# Altium schematic sheet Wifi.SchDoc
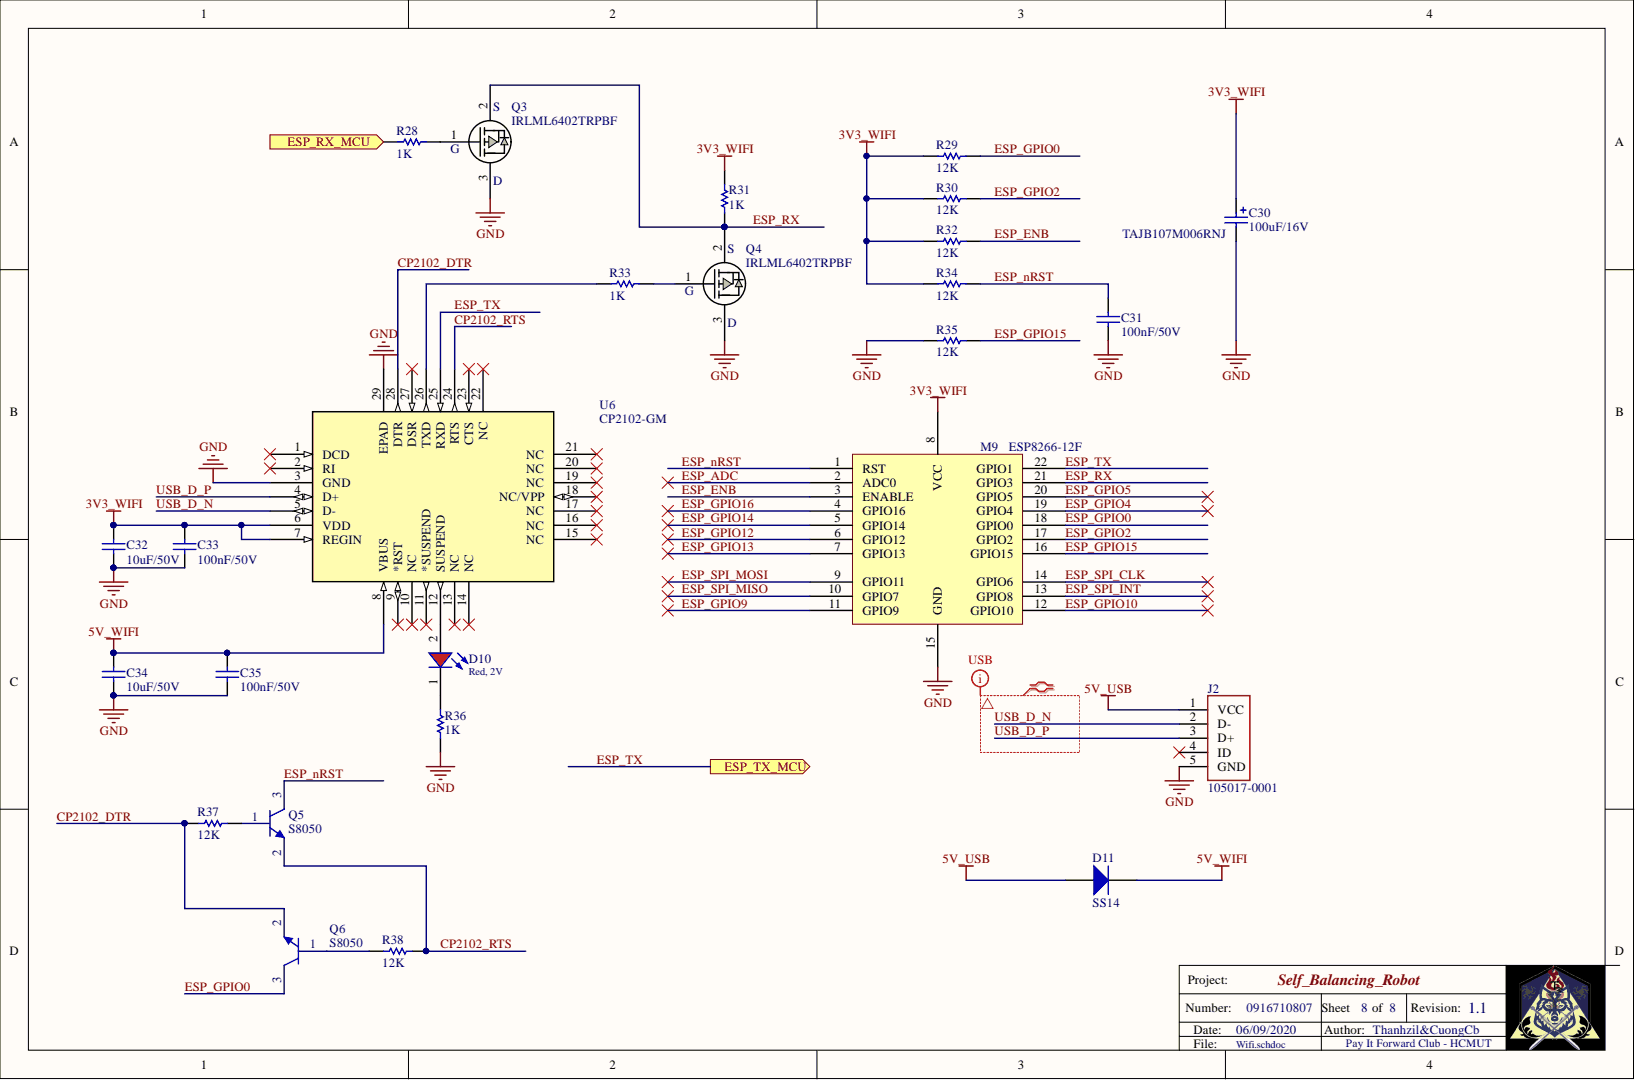
[diagram p0: Wifi.SchDoc]

## Parts
C30  TAJB107M006RNJ  value 100uF/16V  [CAP_SM_TAN]
C31  value 100nF/50V  [CAP-SM-0805]  (hidden comment: Cap-100nF-50V-0805)
C32  value 10uF/50V  [CAP-SM-0805]  (hidden comment: Cap-10uF-50V-0805)
C33  value 100nF/50V  [CAP-SM-0805]  (hidden comment: Cap-100nF-50V-0805)
C34  value 10uF/50V  [CAP-SM-0805]  (hidden comment: Cap-10uF-50V-0805)
C35  value 100nF/50V  [CAP-SM-0805]  (hidden comment: Cap-100nF-50V-0805)
D10  Red, 2V  Forward Voltage typ.: 2V  [WL-SMCW_0805]
D11  SS14  [SS14]
J2  105017-0001  [CONN_105017-0001]
M9  ESP8266-12F  [ESP8266-12F]
Q3  IRLML6402TRPBF  [SOT23_NEX]
Q4  IRLML6402TRPBF  [SOT23_NEX]
Q5  S8050  [SOT23_NEX]
Q6  S8050  [SOT23_NEX]
R28  value 1K  [RES-SM-0805]  (hidden comment: Res-1K-0805)
R29  value 12K  [RES-SM-0805]  (hidden comment: Res-12K-0805)
R30  value 12K  [RES-SM-0805]  (hidden comment: Res-12K-0805)
R31  value 1K  [RES-SM-0805]  (hidden comment: Res-1K-0805)
R32  value 12K  [RES-SM-0805]  (hidden comment: Res-12K-0805)
R33  value 1K  [RES-SM-0805]  (hidden comment: Res-1K-0805)
R34  value 12K  [RES-SM-0805]  (hidden comment: Res-12K-0805)
R35  value 12K  [RES-SM-0805]  (hidden comment: Res-12K-0805)
R36  value 1K  [RES-SM-0805]  (hidden comment: Res-1K-0805)
R37  value 12K  [RES-SM-0805]  (hidden comment: Res-12K-0805)
R38  value 12K  [RES-SM-0805]  (hidden comment: Res-12K-0805)
U6  CP2102-GM  [CP2102-GM]

## Ports
ESP_RX_MCU, ESP_TX_MCU

## Nets
3V3_WIFI: C30.1 C32.1 C33.2 M9.8(VCC) R29.1 R30.1 R31.2 R32.1 R34.1 U6.6(VDD) U6.7(REGIN)
5V_USB: D11.2 J2.1(VCC)
5V_WIFI: C34.2 C35.2 D11.1 U6.8(VBUS)
GND: C30.2 C31.1 C32.2 C33.1 C34.1 C35.1 J2.5(GND) M9.15(GND) Q3.3 Q4.3 R35.1 R36.1 U6.3(GND) U6.29(EPAD)
CP2102_DTR: Q6.2 R37.1 U6.28(DTR)
CP2102_RTS: Q5.2 R38.2 U6.24(RTS)
ESP_ADC: M9.2(ADC0)
ESP_ENB: M9.3(ENABLE) R32.2
ESP_GPIO0: M9.18(GPIO0) Q6.3 R29.2
ESP_GPIO2: M9.17(GPIO2) R30.2
ESP_GPIO4: M9.19(GPIO4)
ESP_GPIO5: M9.20(GPIO5)
ESP_GPIO9: M9.11(GPIO9)
ESP_GPIO10: M9.12(GPIO10)
ESP_GPIO12: M9.6(GPIO12)
ESP_GPIO13: M9.7(GPIO13)
ESP_GPIO14: M9.5(GPIO14)
ESP_GPIO15: M9.16(GPIO15) R35.2
ESP_GPIO16: M9.4(GPIO16)
ESP_nRST: C31.2 M9.1(RST) Q5.3 R34.2
ESP_RX: M9.21(GPIO3) Q3.2 Q4.2 R31.1
ESP_RX_MCU: R28.1 ; port ESP_RX_MCU
ESP_SPI_CLK: M9.14(GPIO6)
ESP_SPI_INT: M9.13(GPIO8)
ESP_SPI_MISO: M9.10(GPIO7)
ESP_SPI_MOSI: M9.9(GPIO11)
ESP_TX: M9.22(GPIO1) U6.25(RXD) ; port ESP_TX_MCU
USB_D_N: J2.2(D-) U6.5(D-)
USB_D_P: J2.3(D+) U6.4(D+)
NetD10_1: D10.1 R36.2
NetD10_2: D10.2 U6.12(SUSPEND)
NetQ3_1: Q3.1 R28.2
NetQ4_1: Q4.1 R33.1
NetQ5_1: Q5.1 R37.2
NetQ6_1: Q6.1 R38.1
NetR33_2: R33.2 U6.26(TXD)
N00269:
N00270:

Not connected: J2 4(ID); U6 1(DCD) 2(RI) 9(*RST) 10(NC) 11(*SUSPEND) 13(NC) 14(NC) 15(NC) 16(NC) 17(NC) 18(NC/VPP) 19(NC) 20(NC) 21(NC) 22(NC) 23(CTS) 27(DSR)

## Library data of the parts
C30 = Cap-Tan-100uF-16V: Manufacturer: Nichicon; Manufacturer Part Number: UWX1C101MCL1GB; Component Kind: Standard; Library Name: Capacitor.SchLib; Local Supplier: icdayroi; Local Supplier Link: https://icdayroi.com/tu-tantalum-100uf-16v-smd6032; Supplier 1: Digikey; Supplier 1 Link: https://www.digikey.com/product-detail/en/nichicon/UWX1C101MCL1GB/493-2105-1-ND/590080; Supplier 1 Part Number: 493-2105-1-ND
C31 C33 C35 = Cap-100nF-50V-0805: CAP CER 0.1UF 50V Y5V 0805; Manufacturer: Samsung Electro-Mechanics; Manufacturer Part Number: CL21F104ZBCNNNC; Component Kind: Standard; Library Name: Capacitor.SchLib; Local Supplier: thegioiic; Local Supplier Link: https://thegioiic.com/products/tu-100nf-0-1uf-50v-0805; Supplier 1: Digikey; Supplier 1 Link: https://www.digikey.com/product-detail/en/samsung-electro-mechanics/CL21F104ZBCNNNC/1276-1007-1-ND/3889093; Supplier 1 Part Number: 1276-1007-1-ND
C32 C34 = Cap-10uF-50V-0805: CAP CER 10UF 50V X5R 0805; Manufacturer: Yageo; Manufacturer Part Number: CC0805KKX5R9BB106; Component Kind: Standard; Library Name: Capacitor.SchLib; Local Supplier: thegioiic; Local Supplier Link: https://thegioiic.com/products/tu-10uf-50v-0805; Supplier 1: Digikey; Supplier 1 Link: https://www.digikey.com/product-detail/en/yageo/CC0805KKX5R9BB106/311-3499-2-ND/7164383; Supplier 1 Part Number: 311-3499-2-ND
D10 = 150080RS75000: WL-SMCW SMT Mono-color Chip LED Waterclear, size 0805, Red, 2V, 140deg; Chip Technology: AlInGaP; Dominant Wavelength typ.: 625nm; Luminous Intensity typ.: 150mcd; Peak Wavelength typ.: 630nm; Component Kind: Standard; ComponentLink1Description: Manufacturer; ComponentLink1URL: http://www.we-online.de; ComponentLink2Description: Datasheet; ComponentLink2URL: https://www.we-online.com/catalog/datasheet/150080RS75000.pdf; Emitting Color: Red; Library Name: LED_150060BS75000_Altium_WL-SMCW_rev20a.intlib; Mounting Technology: SMT; Operating Temperature: -40 to +85degC; Packaging: Tape and Reel; Part Number: 150080RS75000; Published Date: December 2019; Size: 0805; Viewing Angle: 140deg; Publisher: Wurth Elektronik
D11 = Diode-SS14: DIODE SCHOTTKY 40V 1A SMA; Manufacturer: ON Semiconductor; Component Kind: Standard; Library Name: Diode.SchLib; Local Supplier: thegioiic; Local Supplier Link: https://thegioiic.com/products/ss14-sma; Manufacturer Part Number: SS14; Supplier 1: Digikey; Supplier 1 Link: https://www.digikey.com/product-detail/en/on-semiconductor/SS14/SS14CT-ND/965729; Supplier 1 Part Number: SS14CT-ND
J2 = 105017-0001: No Description Available; Built_By: EMA_Cory; SourceLibrary: Molex_2020-05-26; Vendor: Molex Connector Corporation; Component Kind: Standard; Datasheet: https://www.molex.com/pdm_docs/sd/1050170001_sd.pdf; Library Name: Header-connector.SchLib; Manufacturer_Part_Number: 105017-0001; RefDes: RefDes; Type: DEV; Copyright: Copyright (C) 2018 Accelerated Designs. All rights reserved
Q3 Q4 = IRLML6402TRPBF: P-Channel 20V 3.7A (Ta) 1.3W (Ta) Surface Mount Micro3™/SOT-23; Component Kind: Standard; Library Name: MOSFET.SchLib
R28 R31 R33 R36 = Res-1K-0805: RES 1K OHM 1% 1/4W 0805; Manufacturer: Stackpole Electronics Inc; Manufacturer Part Number: RNCP0805FTD1K00; Component Kind: Standard; Library Name: Resistor.SchLib; Local Supplier: thegioiic; Local Supplier Link: https://thegioiic.com/products/dien-tro-1k-ohm-0805-5; Supplier 1: Digikey; Supplier 1 Link: https://www.digikey.com/product-detail/en/stackpole-electronics-inc/RNCP0805FTD1K00/RNCP0805FTD1K00CT-ND/2240568; Supplier 1 Part Number: RNCP0805FTD1K00CT-ND
R29 R30 R32 R34 R35 R37 R38 = Res-12K-0805: RES 12 KOHM JUMPER 0805; Manufacturer: Vishay Thin Film; Manufacturer Part Number: PAT0805E3121BST1; Component Kind: Standard; Library Name: Resistor.SchLib; Local Supplier: icdayroi; Local Supplier Link: https://icdayroi.com/dien-tro-12k-0805-1-goi-50-con; Supplier 1: Digikey; Supplier 1 Link: https://www.digikey.com/product-detail/en/vishay-thin-film/PAT0805E3121BST1/PAT0805E3121BST1-ND/2281536; Supplier 1 Part Number: PAT0805E3121BST1-ND
U6 = CP2102-GM: Built_By: EMA_UL_Team; SourceLibrary: Silicon_Labs_2015-03-23; Vendor: Silicon Labs; Component Kind: Standard; Manufacturer_Part_Number: CP2102-GM; RefDes: RefDes; Type: DEV; Copyright: Copyright (C) 2018 Accelerated Designs. All rights reserved; Library Name: PIFWRoBot.SchLib
M9 = ESP8266-12F: Component Kind: Standard; Local Supplier: HShop; Local Supplier Link: https://hshop.vn/products/mach-thu-phat-wifi-soc-esp8266-v12; Local Supplier Link Part Number: HS000912; Library Name: PIFWRoBot.SchLib
Q5 Q6 = S8050: Component Kind: Standard; Library Name: BJT.SchLib
